# Altium schematic sheet SCH003 - VSC742x CPU memory, debug ports.SchDoc
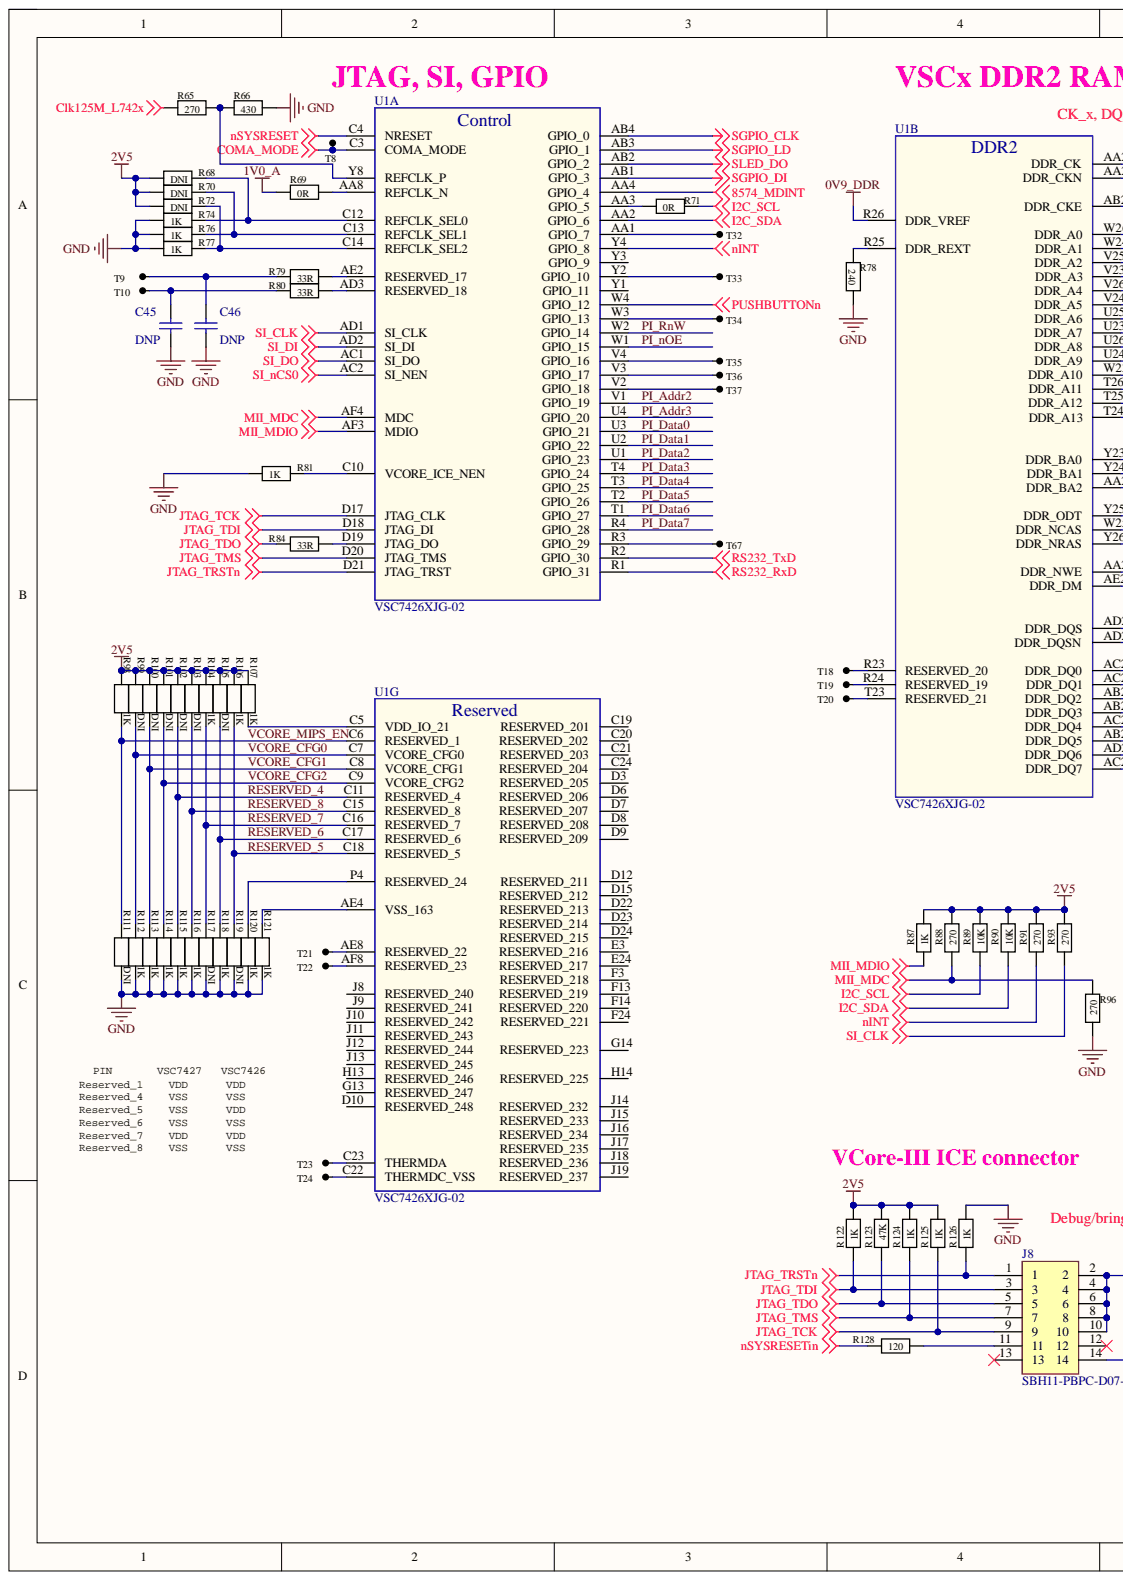
[diagram p0: SCH003 - VSC742x CPU memory, debug ports.SchDoc, left]
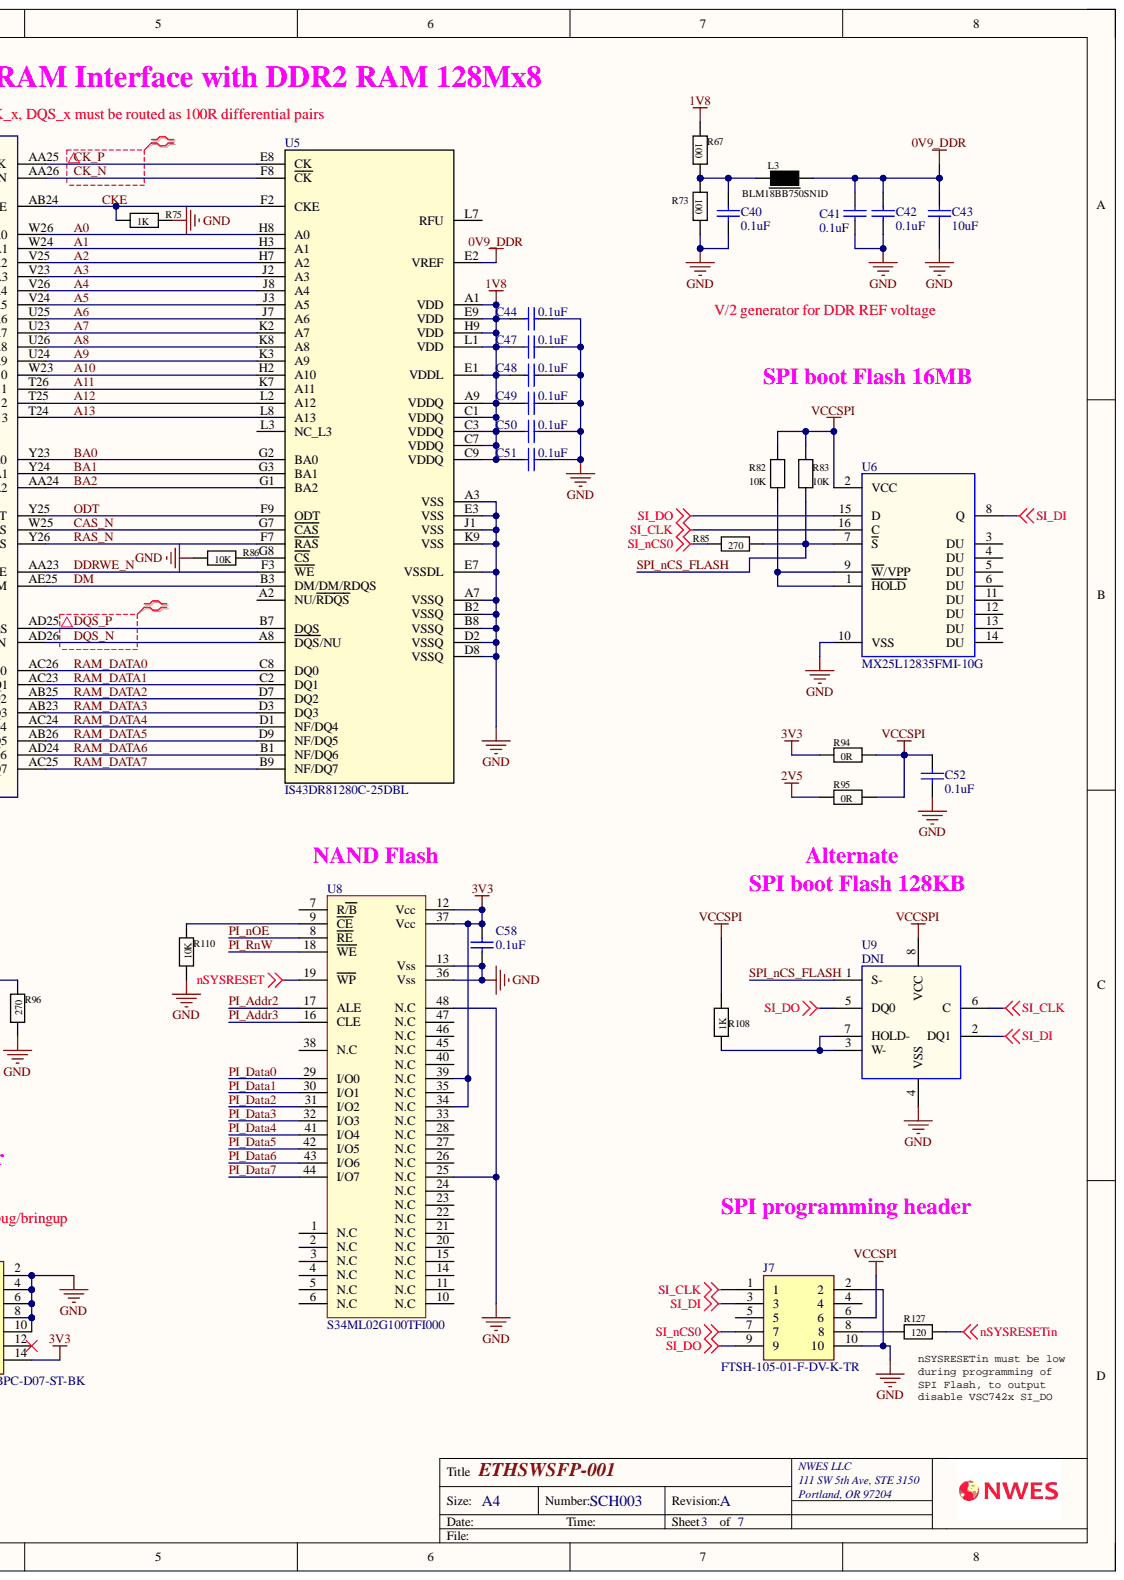
[diagram p1: SCH003 - VSC742x CPU memory, debug ports.SchDoc, right]

## Parts
C40  0.1uF  [CAPC1005X61USR]
C41  0.1uF  [CAPC1005X61USR]
C42  0.1uF  [CAPC1005X61USR]
C43  10uF  [CAPC1005X61USR]
C44  0.1uF  [CAPC1005X61USR]
C45  DNP  [CAPC1005X61USR]
C46  DNP  [CAPC1005X61USR]
C47  0.1uF  [CAPC1005X61USR]
C48  0.1uF  [CAPC1005X61USR]
C49  0.1uF  [CAPC1005X61USR]
C50  0.1uF  [CAPC1005X61USR]
C51  0.1uF  [CAPC1005X61USR]
C52  0.1uF  [CAPC1005X61USR]
C58  0.1uF  [CAPC1005X61USR]
J7  FTSH-105-01-F-DV-K-TR  [FTSH-105-01-XXX-DV-K]
J8  SBH11-PBPC-D07-ST-BK  [SULLINS_SBH11-PBPC-D07-ST-BK]
L3  BLM18BB750SN1D  [0603_FB]
R65  270  [RESC1005X40USR]
R66  430  [RESC1005X40USR]
R67  100  [RESC1005X40USR]
R68  DNI  [RESC1005X40USR]
R69  0R  [RESC1005X40USR]
R70  DNI  [RESC1005X40USR]
R71  0R  [RESC1005X40USR]
R72  DNI  [RESC1005X40USR]
R73  100  [RESC1005X40USR]
R74  1K  [RESC1005X40USR]
R75  1K  [RESC1005X40USR]
R76  1K  [RESC1005X40USR]
R77  1K  [RESC1005X40USR]
R78  240  [RESC1005X40USR]
R79  33R  [RESC1005X40USR]
R80  33R  [RESC1005X40USR]
R81  1K  [RESC1005X40USR]
R82  10K  [RESC1005X40USR]
R83  10K  [RESC1005X40USR]
R84  33R  [RESC1005X40USR]
R85  270  [RESC1005X40USR]
R86  10K  [RESC1005X40USR]
R87  1K  [RESC1005X40USR]
R88  270  [RESC1005X40USR]
R89  10K  [RESC1005X40USR]
R90  10K  [RESC1005X40USR]
R91  270  [RESC1005X40USR]
R93  270  [RESC1005X40USR]
R94  0R  [RESC1005X40USR]
R95  0R  [RESC1005X40USR]
R96  270  [RESC1005X40USR]
R98  1K  [RESC1005X40USR]
R99  DNI  [RESC1005X40USR]
R100  DNI  [RESC1005X40USR]
R101  DNI  [RESC1005X40USR]
R102  DNI  [RESC1005X40USR]
R103  DNI  [RESC1005X40USR]
R104  1K  [RESC1005X40USR]
R105  DNI  [RESC1005X40USR]
R106  1K  [RESC1005X40USR]
R107  1K  [RESC1005X40USR]
R108  1K  [RESC1005X40USR]
R110  10K  [RESC1005X40USR]
R111  DNI  [RESC1005X40USR]
R112  1K  [RESC1005X40USR]
R113  1K  [RESC1005X40USR]
R114  1K  [RESC1005X40USR]
R115  1K  [RESC1005X40USR]
R116  1K  [RESC1005X40USR]
R117  DNI  [RESC1005X40USR]
R118  1K  [RESC1005X40USR]
R119  DNI  [RESC1005X40USR]
R120  1K  [RESC1005X40USR]
R121  1K  [RESC1005X40USR]
R122  1K  [RESC1005X40USR]
R123  47K  [RESC1005X40USR]
R124  1K  [RESC1005X40USR]
R125  1K  [RESC1005X40USR]
R126  1K  [RESC1005X40USR]
R127  120  [RESC1005X40USR]
R128  120  [RESC1005X40USR]
T8  TP_S  [TP 1mm]  (value not drawn)  (no BOM)
T9  TP_S  [TP 1mm]  (value not drawn)  (no BOM)
T10  TP_S  [TP 1mm]  (value not drawn)  (no BOM)
T18  TP_S  [TP 1mm]  (value not drawn)  (no BOM)
T19  TP_S  [TP 1mm]  (value not drawn)  (no BOM)
T20  TP_S  [TP 1mm]  (value not drawn)  (no BOM)
T21  TP_S  [TP 1mm]  (value not drawn)  (no BOM)
T22  TP_S  [TP 1mm]  (value not drawn)  (no BOM)
T23  TP_S  [TP 1mm]  (value not drawn)  (no BOM)
T24  TP_S  [TP 1mm]  (value not drawn)  (no BOM)
T32  TP_S  [TP 1mm]  (value not drawn)  (no BOM)
T33  TP_S  [TP 1mm]  (value not drawn)  (no BOM)
T34  TP_S  [TP 1mm]  (value not drawn)  (no BOM)
T35  TP_S  [TP 1mm]  (value not drawn)  (no BOM)
T36  TP_S  [TP 1mm]  (value not drawn)  (no BOM)
T37  TP_S  [TP 1mm]  (value not drawn)  (no BOM)
T67  TP_S  [TP 1mm]  (value not drawn)  (no BOM)
U1  VSC7426XJG-02  [BGA672C100P26X26_2700X2700X244]  (parts A,B,G)
U5  IS43DR81280C-25DBL  [FBGA60_8X10.5]
U6  MX25L12835FMI-10G  [M25P128_SO16_10P50X7P60_MRN]
U8  S34ML02G100TFI000  [TSOP48]
U9  DNI  [M25P10_SO8N_5X4_MRN]

## Nets
0V9_DDR: C41.2 C42.2 C43.1 L3.2 U1.R26(DDR_VREF) U5.E2(VREF)
1V0_A: R69.2
1V8: C44.1 C47.1 C48.1 C49.1 C50.1 C51.1 R67.1 U5.A1(VDD) U5.A9(VDDQ) U5.C1(VDDQ) U5.C3(VDDQ) U5.C7(VDDQ) U5.C9(VDDQ) U5.E1(VDDL) U5.E9(VDD) U5.H9(VDD) U5.L1(VDD)
2V5: R68.2 R70.2 R72.2 R87.1 R88.1 R89.1 R90.1 R91.1 R93.1 R95.2 R98.1 R99.1 R100.1 R101.1 R102.1 R103.1 R104.1 R105.1 R106.1 R107.1 R122.1 R123.1 R124.1 R125.1
3V3: C58.2 J8.14 R94.2 U8.12(Vcc) U8.34(N.C) U8.37(Vcc) U8.39(N.C)
8574_MDINT: U1.AA4(GPIO_4)
Clk125M_L742x: R65.2
COMA_MODE: T8.1 U1.C3(COMA_MODE)
GND: C40.1 C41.1 C42.1 C43.2 C44.2 C45.2 C46.2 C47.2 C48.2 C49.2 C50.2 C51.2 C52.1 C58.1 J7.2 J7.10 J8.2 J8.4 J8.6 J8.8 J8.10 R66.1 R73.2 R74.2 R75.1 R76.2 R77.2 R78.2 R81.2 R86.2 R96.2 R110.2 R111.2 R112.2 R113.2 R114.2 R115.2 R116.2 R117.2 R118.2 R119.2 R120.2 R121.2 R126.1 U5.A3(VSS) U5.A7(VSSQ) U5.B2(VSSQ) U5.B8(VSSQ) U5.D2(VSSQ) U5.D8(VSSQ) U5.E3(VSS) U5.E7(VSSDL) U5.J1(VSS) U5.K9(VSS) U6.10(VSS) U8.13(Vss) U8.25(N.C) U8.36(Vss) U8.48(N.C) U9.4(VSS)
I2C_SCL: R71.1 R89.2
I2C_SDA: R90.2 U1.AA2(GPIO_6)
JTAG_TCK: J8.9 R125.2 U1.D17(JTAG_CLK)
JTAG_TDI: J8.3 R122.2 U1.D18(JTAG_DI)
JTAG_TDO: J8.5 R84.2 R123.2
JTAG_TMS: J8.7 R124.2 U1.D20(JTAG_TMS)
JTAG_TRSTn: J8.1 R126.2 U1.D21(JTAG_TRST)
MII_MDC: R88.2 R96.1 U1.AF4(MDC)
MII_MDIO: R87.2 U1.AF3(MDIO)
nINT: R91.2 U1.Y4(GPIO_8)
nSYSRESET: U1.C4(NRESET) U8.19(WP)
nSYSRESETin: R127.2 R128.1
PUSHBUTTONn: U1.W4(GPIO_12)
RS232_RxD: U1.R1(GPIO_31)
RS232_TxD: U1.R2(GPIO_30)
SGPIO_CLK: U1.AB4(GPIO_0)
SGPIO_DI: U1.AB1(GPIO_3)
SGPIO_LD: U1.AB3(GPIO_1)
SI_CLK: J7.1 R93.2 U1.AD1(SI_CLK) U6.16(C) U9.6(C)
SI_DI: J7.3 U1.AD2(SI_DI) U6.8(Q) U9.2(DQ1)
SI_DO: J7.9 U1.AC1(SI_DO) U6.15(D) U9.5(DQ0)
SI_nCS0: J7.7 R85.1 U1.AC2(SI_NEN)
SLED_DO: U1.AB2(GPIO_2)
VCCSPI: C52.2 J7.6 R82.1 R83.1 R94.1 R95.1 R108.1 U6.2(VCC) U9.8(VCC)
A0: U1.W26(DDR_A0) U5.H8(A0)
A1: U1.W24(DDR_A1) U5.H3(A1)
A2: U1.V25(DDR_A2) U5.H7(A2)
A3: U1.V23(DDR_A3) U5.J2(A3)
A4: U1.V26(DDR_A4) U5.J8(A4)
A5: U1.V24(DDR_A5) U5.J3(A5)
A6: U1.U25(DDR_A6) U5.J7(A6)
A7: U1.U23(DDR_A7) U5.K2(A7)
A8: U1.U26(DDR_A8) U5.K8(A8)
A9: U1.U24(DDR_A9) U5.K3(A9)
A10: U1.W23(DDR_A10) U5.H2(A10)
A11: U1.T26(DDR_A11) U5.K7(A11)
A12: U1.T25(DDR_A12) U5.L2(A12)
A13: U1.T24(DDR_A13) U5.L8(A13)
BA0: U1.Y23(DDR_BA0) U5.G2(BA0)
BA1: U1.Y24(DDR_BA1) U5.G3(BA1)
BA2: U1.AA24(DDR_BA2) U5.G1(BA2)
CAS_N: U1.W25(DDR_NCAS) U5.G7(CAS)
CK_N: U1.AA26(DDR_CKN) U5.F8(CK)
CK_P: U1.AA25(DDR_CK) U5.E8(CK)
CKE: R75.2 U1.AB24(DDR_CKE) U5.F2(CKE)
DDRWE_N: U1.AA23(DDR_NWE) U5.F3(WE)
DM: U1.AE25(DDR_DM) U5.B3(DM/DM/RDQS)
DQS_N: U1.AD26(DDR_DQSN) U5.A8(DQS/NU)
DQS_P: U1.AD25(DDR_DQS) U5.B7(DQS)
ODT: U1.Y25(DDR_ODT) U5.F9(ODT)
PI_Addr2: U1.V1(GPIO_19) U8.17(ALE)
PI_Addr3: U1.U4(GPIO_20) U8.16(CLE)
PI_Data0: U1.U3(GPIO_21) U8.29(I/O0)
PI_Data1: U1.U2(GPIO_22) U8.30(I/O1)
PI_Data2: U1.U1(GPIO_23) U8.31(I/O2)
PI_Data3: U1.T4(GPIO_24) U8.32(I/O3)
PI_Data4: U1.T3(GPIO_25) U8.41(I/O4)
PI_Data5: U1.T2(GPIO_26) U8.42(I/O5)
PI_Data6: U1.T1(GPIO_27) U8.43(I/O6)
PI_Data7: U1.R4(GPIO_28) U8.44(I/O7)
PI_nOE: U1.W1(GPIO_15) U8.8(RE)
PI_RnW: U1.W2(GPIO_14) U8.18(WE)
RAM_DATA0: U1.AC26(DDR_DQ0) U5.C8(DQ0)
RAM_DATA1: U1.AC23(DDR_DQ1) U5.C2(DQ1)
RAM_DATA2: U1.AB25(DDR_DQ2) U5.D7(DQ2)
RAM_DATA3: U1.AB23(DDR_DQ3) U5.D3(DQ3)
RAM_DATA4: U1.AC24(DDR_DQ4) U5.D1(NF/DQ4)
RAM_DATA5: U1.AB26(DDR_DQ5) U5.D9(NF/DQ5)
RAM_DATA6: U1.AD24(DDR_DQ6) U5.B1(NF/DQ6)
RAM_DATA7: U1.AC25(DDR_DQ7) U5.B9(NF/DQ7)
RAS_N: U1.Y26(DDR_NRAS) U5.F7(RAS)
RESERVED_4: R102.2 R115.1 U1.C11(RESERVED_4)
RESERVED_5: R106.2 R119.1 U1.C18(RESERVED_5)
RESERVED_6: R105.2 R118.1 U1.C17(RESERVED_6)
RESERVED_7: R104.2 R117.1 U1.C16(RESERVED_7)
RESERVED_8: R103.2 R116.1 U1.C15(RESERVED_8)
SPI_nCS_FLASH: R83.2 R85.2 U6.7(S) U9.1(S-)
VCORE_CFG0: R99.2 R112.1 U1.C7(VCORE_CFG0)
VCORE_CFG1: R100.2 R113.1 U1.C8(VCORE_CFG1)
VCORE_CFG2: R101.2 R114.1 U1.C9(VCORE_CFG2)
VCORE_MIPS_EN: R98.2 R111.1 U1.C6(RESERVED_1)
NetC40_2: C40.2 L3.1 R67.2 R73.1
NetC45_1: C45.1 R80.2 T10.1
NetC46_1: C46.1 R79.2 T9.1
NetJ7_8: J7.8 R127.1
NetJ8_11: J8.11 R128.2
NetR65_1: R65.1 R66.2 U1.Y8(REFCLK_P)
NetR68_1: R68.1 R74.1 U1.C12(REFCLK_SEL0)
NetR69_1: R69.1 U1.AA8(REFCLK_N)
NetR70_1: R70.1 R76.1 U1.C13(REFCLK_SEL1)
NetR71_2: R71.2 U1.AA3(GPIO_5)
NetR72_1: R72.1 R77.1 U1.C14(REFCLK_SEL2)
NetR78_1: R78.1 U1.R25(DDR_REXT)
NetR79_1: R79.1 U1.AE2(RESERVED_17)
NetR80_1: R80.1 U1.AD3(RESERVED_18)
NetR81_1: R81.1 U1.C10(VCORE_ICE_NEN)
NetR82_2: R82.2 U6.1(HOLD) U6.9(W/VPP)
NetR84_1: R84.1 U1.D19(JTAG_DO)
NetR86_1: R86.1 U5.G8(CS)
NetR107_2: R107.2 U1.C5(VDD_IO_21)
NetR108_2: R108.2 U9.3(W-) U9.7(HOLD-)
NetR110_1: R110.1 U8.9(CE)
NetR120_1: R120.1 U1.P4(RESERVED_24)
NetR121_1: R121.1 U1.AE4(VSS_163)
NetT18_1: T18.1 U1.R23(RESERVED_20)
NetT19_1: T19.1 U1.R24(RESERVED_19)
NetT20_1: T20.1 U1.T23(RESERVED_21)
NetT21_1: T21.1 U1.AE8(RESERVED_22)
NetT22_1: T22.1 U1.AF8(RESERVED_23)
NetT23_1: T23.1 U1.C23(THERMDA)
NetT24_1: T24.1 U1.C22(THERMDC_VSS)
NetT32_1: T32.1 U1.AA1(GPIO_7)
NetT33_1: T33.1 U1.Y2(GPIO_10)
NetT34_1: T34.1 U1.W3(GPIO_13)
NetT35_1: T35.1 U1.V4(GPIO_16)
NetT36_1: T36.1 U1.V3(GPIO_17)
NetT37_1: T37.1 U1.V2(GPIO_18)
NetT67_1: T67.1 U1.R3(GPIO_29)

Not connected: J7 4 5; J8 12 13; U1 C19(RESERVED_201) C20(RESERVED_202) C21(RESERVED_203) C24(RESERVED_204) D3(RESERVED_205) D6(RESERVED_206) D7(RESERVED_207) D8(RESERVED_208) D9(RESERVED_209) D10(RESERVED_248) D12(RESERVED_211) D15(RESERVED_212) D22(RESERVED_213) D23(RESERVED_214) D24(RESERVED_215) E3(RESERVED_216) E24(RESERVED_217) F3(RESERVED_218) F13(RESERVED_219) F14(RESERVED_220) F24(RESERVED_221) G13(RESERVED_247) G14(RESERVED_223) H13(RESERVED_246) H14(RESERVED_225) J8(RESERVED_240) J9(RESERVED_241) J10(RESERVED_242) J11(RESERVED_243) J12(RESERVED_244) J13(RESERVED_245) J14(RESERVED_232) J15(RESERVED_233) J16(RESERVED_234) J17(RESERVED_235) J18(RESERVED_236) J19(RESERVED_237) Y1(GPIO_11) Y3(GPIO_9); U5 A2(NU/RDQS) L3(NC_L3) L7(RFU); U6 3(DU) 4(DU) 5(DU) 6(DU) 11(DU) 12(DU) 13(DU) 14(DU); U8 1(N.C) 2(N.C) 3(N.C) 4(N.C) 5(N.C) 6(N.C) 7(R/B) 10(N.C) 11(N.C) 14(N.C) 15(N.C) 20(N.C) 21(N.C) 22(N.C) 23(N.C) 24(N.C) 26(N.C) 27(N.C) 28(N.C) 33(N.C) 35(N.C) 38(N.C) 40(N.C) 45(N.C) 46(N.C) 47(N.C)

## Library data of the parts
C40-C42 C44 C47-C52 C58 = CAP CER 0.1UF 50V X7R 0402: Manufacturer: Murata Electronics; Manufacturer Part Number: GRM155R71H104KE14D; Component Kind: Standard; Description: CAP CER 0.1UF 50V X7R 0402; Package: 0402; Supplier 1: Digi-Key; Supplier Part Number 1: 490-10700-2-ND; value: 0.1uF; Library Name: 15-port switch V1.SCHLIB
C43 = CAP CER 10UF 10V X5R 0402: Manufacturer: Samsung Electro-Mechanics; Manufacturer Part Number: CL05A106MP8NUB8; Component Kind: Standard; Description: CAP CER 10UF 10V X5R 0402; Package: 0402; Supplier 1: Digi-Key; Supplier Part Number 1: 1276-6830-1-ND; value: 10uF; Library Name: 15-port switch V1.SCHLIB
C45 C46 = Cap: CAP CER 15PF 50V C0G/NP0 0402; Manufacturer: Murata Electronics; Manufacturer Part Number: GCM1555C1H150JA16D; Component Kind: Standard; Supplier 1: Digi-Key; Supplier Part Number 1: 490-11147-1-ND; value: 15pF; Library Name: 15-port switch V1.SCHLIB
J7 = FTSH-105-01-L-DV-K-A-P-TR: CONN HEADER SMD 10POS 1.27MM; Manufacturer: SAMTEC; Component Kind: Standard; Datasheet Link: http://suddendocs.samtec.com/prints/ftsh-1xx-xx-xxx-dv-xxx-xxx-mkt.pdf; Height: 6.1mm; Manufacturer Part Number: FTSH-105-01-F-DV-K-TR; RS Part Number: 1802978P; RS Price/Stock: http://uk.rs-online.com/web/p/products/1802978P; Supplier 1: Digi-Key; Supplier Part Number 1: SAM13160CT-ND; Library Name: 15-port switch V1.SCHLIB
J8 = SBH11-PBPC-D07-ST-BK: Connector Header Through Hole 14 position 0.100 _2.54mm_; Manufacturer: Sullins Connector Solutions; Component Kind: Standard; Manufacturer Part Number: SBH11-PBPC-D07-ST-BK; Supplier 1: Digi-Key; Supplier Part Number 1: S9170-ND; Value: SBH11-PBPC-D07-ST-BK; Library Name: 15-port switch V1.SCHLIB
L3 = L1: FERRITE BEAD 75 OHM 0603 1LN; Manufacturer: TDK Corporation; Manufacturer Part Number: MMZ1608F750BTD25; Current Rating: 300mA; Value: 75ohm; Component Kind: Standard; Supplier 1: Digi-Key; Supplier Part Number 1: 445-172823-1-ND; Library Name: 15-port switch V1.SCHLIB
R65 R85 R88 R91 R93 R96 = RES 270 OHM 1% 1/16W 0402: Manufacturer: Yageo; Manufacturer Part Number: RC0402FR-07270RL; Component Kind: Standard; Description: RES 270 OHM 1% 1/16W 0402; Height: 0.40mm; Package: 0402; Supplier 1: Digi-Key; Supplier Part Number 1: 311-270LRCT-ND; Value: 270; Library Name: 15-port switch V1.SCHLIB
R66 = RES 430 OHM 1% 1/16W 0402: Manufacturer: Yageo; Manufacturer Part Number: RC0402FR-07430RL; Component Kind: Standard; Description: RES 430 OHM 1% 1/16W 0402; Height: 0.40mm; Package: 0402; Supplier 1: Digi-Key; Supplier Part Number 1: 311-430LRCT-ND; Value: 430; Library Name: 15-port switch V1.SCHLIB
R67 R73 = RES 100 OHM 1% 1/16W 0402: Manufacturer: Yageo; Manufacturer Part Number: RC0402FR-07100RL; Component Kind: Standard; Description: RES 100 OHM 1% 1/16W 0402; Height: 0.40mm; Package: 0402; Supplier 1: Digi-Key; Supplier Part Number 1: 311-100LRCT-ND; Value: 100; Library Name: 15-port switch V1.SCHLIB
R68 R70 R72 R99-R103 R105 R111 R117 R119 = RES DNI: RES 0 OHM JUMPER 1/16W 0402; Manufacturer: Yageo; Manufacturer Part Number: RC0402FR-070RL; Value: 0R; Component Kind: Standard; Height: 0.40mm; Package: 0402; Supplier 1: Digi-Key; Supplier Part Number 1: 311-0.0LRCT-ND; Library Name: 15-port switch V1.SCHLIB
R69 R71 R94 R95 = RES 0 OHM JUMPER 1/16W 0402: Manufacturer: Yageo; Manufacturer Part Number: RC0402FR-070RL; Component Kind: Standard; Description: RES 0 OHM JUMPER 1/16W 0402; Height: 0.40mm; Package: 0402; Supplier 1: Digi-Key; Supplier Part Number 1: 311-0.0LRCT-ND; Value: 0R; Library Name: 15-port switch V1.SCHLIB
R74-R77 R81 R87 R98 R104 R106-R108 R112-R116 R118 R120-R122 R124-R126 = RES SMD 1K OHM 1% 1/16W 0402: Manufacturer: Yageo; Manufacturer Part Number: RC0402FR-071KP; Component Kind: Standard; Description: RES SMD 1K OHM 1% 1/16W 0402; Height: 0.40mm; Package: 0402; Supplier 1: Digi-Key; Supplier Part Number 1: YAG1254CT-ND; Value: 1K; Library Name: 15-port switch V1.SCHLIB
R78 = RES 240 OHM 1% 1/16W 0402: Manufacturer: Yageo; Manufacturer Part Number: RC0402FR-07240RL; Component Kind: Standard; Description: RES 240 OHM 1% 1/16W 0402; Height: 0.40mm; Package: 0402; Supplier 1: Digi-Key; Supplier Part Number 1: 311-240LRCT-ND; Value: 240; Library Name: 15-port switch V1.SCHLIB
R79 R80 R84 = RES 33 OHM 1% 1/16W 0402: Manufacturer: Yageo; Manufacturer Part Number: RC0402FR-0733RL; Component Kind: Standard; Description: RES 33 OHM 1% 1/16W 0402; Height: 0.40mm; Package: 0402; Supplier 1: Digi-Key; Supplier Part Number 1: 311-33.0LRCT-ND; Value: 33R; Library Name: 15-port switch V1.SCHLIB
R82 R83 R86 R89 R90 R110 = RES SMD 10K OHM 1% 1/16W 0402: Manufacturer: Yageo; Manufacturer Part Number: AC0402FR-0710KL; Component Kind: Standard; Description: RES SMD 10K OHM 1% 1/16W 0402; Height: 0.40mm; Package: 0402; Supplier 1: Digi-Key; Supplier Part Number 1: YAG3436TR-ND; Value: 10K; Library Name: 15-port switch V1.SCHLIB
R123 = RES 47K OHM 1% 1/16W 0402: Manufacturer: Stackpole Electronics Inc; Manufacturer Part Number: RMCF0402FT47K0; Component Kind: Standard; Description: RES 47K OHM 1% 1/16W 0402; Height: 0.40mm; Package: 0402; Supplier 1: Digi-Key; Supplier Part Number 1: RMCF0402FT47K0CT-ND; Value: 47K; Library Name: 15-port switch V1.SCHLIB
R127 R128 = RES 120 OHM 1% 1/16W 0402: Manufacturer: Yageo; Manufacturer Part Number: RC0402FR-07120RL; Component Kind: Standard; Description: RES 120 OHM 1% 1/16W 0402; Height: 0.40mm; Package: 0402; Supplier 1: Digi-Key; Supplier Part Number 1: 311-120LRCT-ND; Value: 120; Library Name: 15-port switch V1.SCHLIB
T8-T10 T18-T24 T32-T37 T67 = TP_S: test point; Library Name: 26-port switch.SCHLIB
U1 = VSC7426XJG-02: IC TELECOM INTERFACE 672BGA; Manufacturer: Microchip Technology; Geometry.Height: 2.44mm; Component Kind: Standard; Manufacturer Part Number: VSC7426XJG-02; Supplier Part Number 1: VSC7426XJG-02-ND; Library Name: 15-port switch V1.SCHLIB
U5 = IS43DR81280C-25DBL: IC DRAM 1GBIT PARALLEL 60TWBGA; Manufacturer: ISSI; Vendor: Micron; Component Kind: Standard; Manufacturer Part Number: IS43DR81280C-25DBL; RefDes: RefDes; Supplier 1: Mouser; Supplier Part Number 1: 870-43DR81280C-25DBL; Type: DEV; Library Name: 15-port switch V1.SCHLIB
U6 = M25P128-VMF6P: IC FLSH 128MBIT SPI 104MHZ 16SOP; Manufacturer: Macronix; Vendor: Flip Electronics; Component Kind: Standard; Manufacturer Part Number: MX25L12835FMI-10G; RefDes: RefDes; Supplier 1: Digi-Key; Supplier Part Number 1: 1092-1147-ND; Type: DEV; Library Name: 15-port switch V1.SCHLIB
U8 = K9F2G08U0A-PCB0: IC FLASH 2GBIT PARALLEL 48TSOP I; Manufacturer: Cypress Semiconductor Corp; Component Kind: Standard; Manufacturer Part Number: S34ML02G100TFI000; Supplier 1: Digi-Key; Supplier Part Number 1: 1274-1040-ND; Library Name: 26-port switch V1.SCHLIB
U9 = M25P10-AVMN6PYA: IC FLASH 1MBIT SPI/QUAD 8SOIC; Manufacturer: ISSI, Integrated Silicon Solution Inc; Manufacturer Part Number: IS25LQ010B-JNLE; SourceLibrary: Micron_2018-04-06; Component Kind: Standard; RefDes: RefDes; Supplier 1: Digi-Key; Supplier Part Number 1: 706-1322-ND; Type: DEV; Library Name: 15-port switch V1.SCHLIB
